# Altium schematic sheet Main.SchDoc
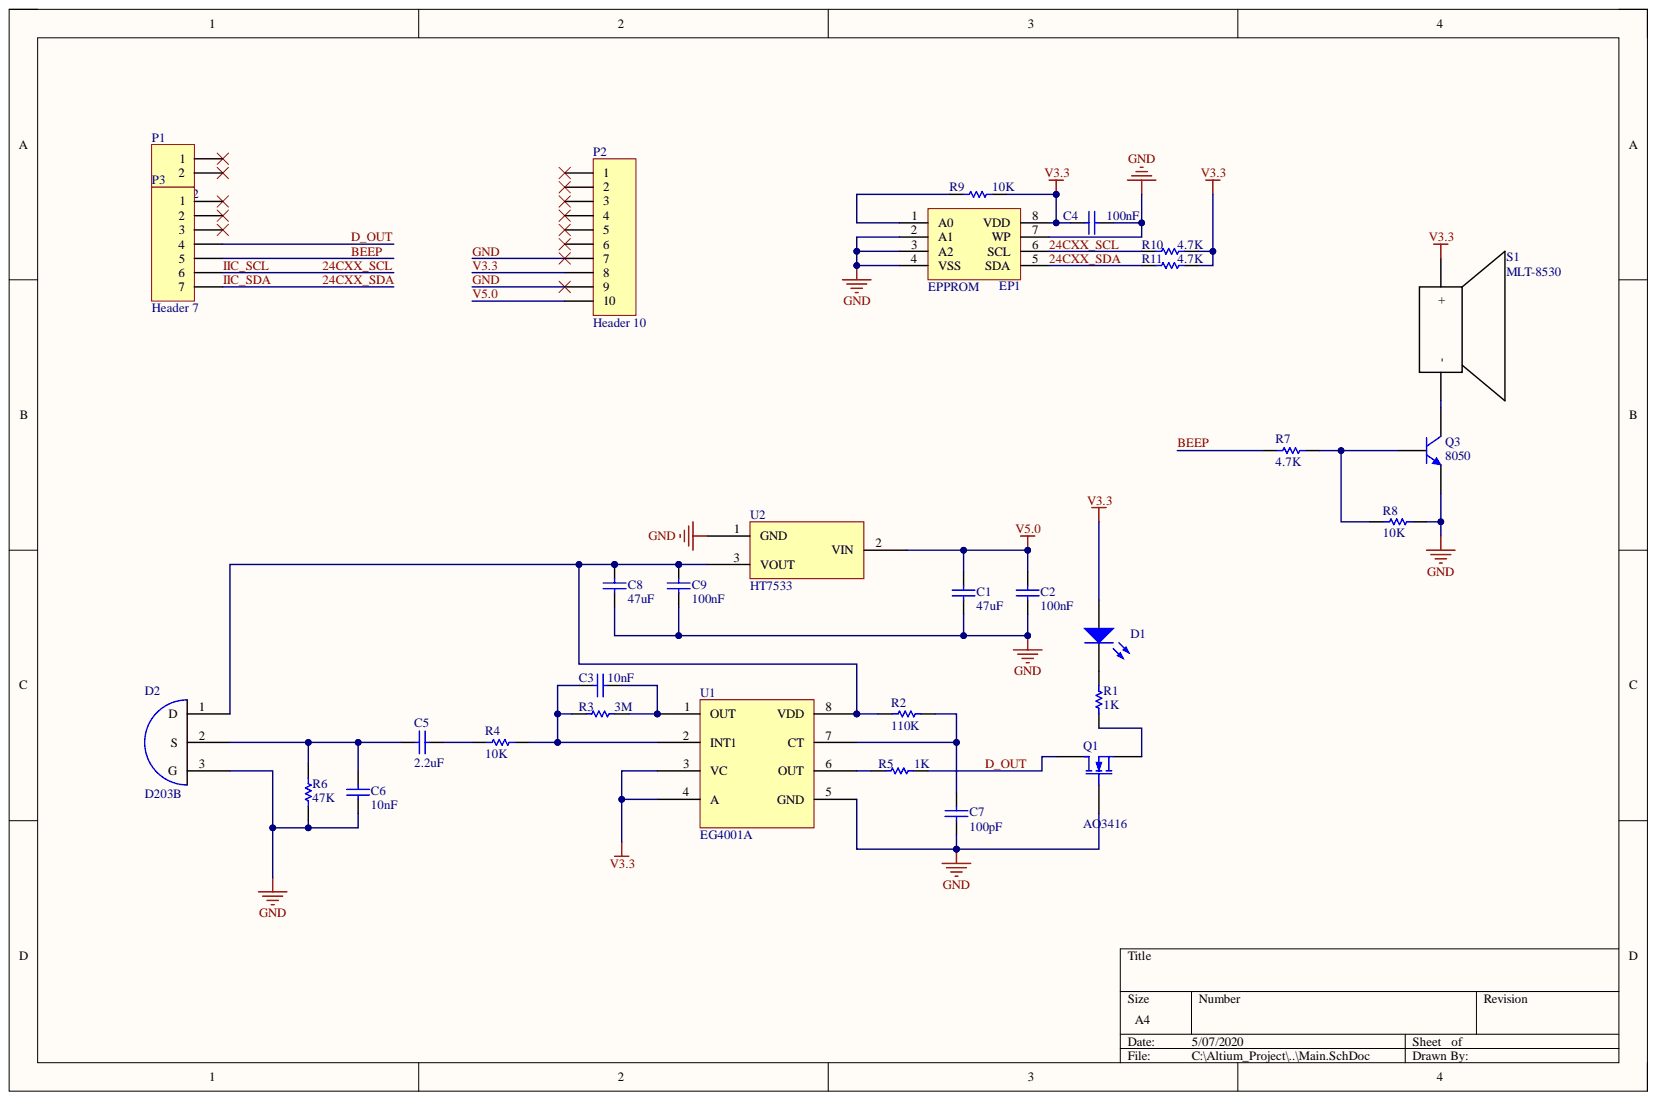
[diagram p0: Main.SchDoc]

## Parts
C1  47uF  [LC-0805_C]
C2  100nF  [C0603]
C3  10nF  [C0603]
C4  100nF  [C0603]
C5  2.2uF  [C0603]
C6  10nF  [C0603]
C7  100pF  [C0603]
C8  47uF  [LC-0805_C]
C9  100nF  [C0603]
D1  LED  [LED_0805]  (value not drawn)
D2  D203B  [D203B]
EP1  EPPROM  [SO-8]
P1  Header 2  [HDR1X2]
P2  Header 10  [HDR1X10]
P3  Header 7  [HDR1X7]
Q1  AO3416  [AO341X]
Q3  8050  [SOT23_N - 123]
R1  1K  [R0603]
R2  110K  [R0603]
R3  3M  [R0603]
R4  10K  [R0603]
R5  1K  [R0603]
R6  47K  [R0603]
R7  4.7K  [R0603]
R8  10K  [R0603]
R9  10K  [R0603]
R10  4.7K  [R0603]
R11  4.7K  [R0603]
S1  MLT-8530  [MLT8530]
U1  EG4001A  [EG4001A]
U2  HT7533  [SOT-89]

## Nets
24CXX_SCL: EP1.6(SCL) P3.6 R10.2
24CXX_SDA: EP1.5(SDA) P3.7 R11.2
BEEP: P3.5 R7.1
D_OUT: P3.4 Q1.1 R5.2
GND: C1.1 C2.1 C4.1 C6.1 C7.1 C8.1 C9.1 D2.3 EP1.2(A1) EP1.3(A2) EP1.4(VSS) EP1.7(WP) P2.7 P2.9 Q1.2 Q3.2 R6.1 R8.2 U1.5(GND) U2.1
V3.3: C4.2 D1.1 EP1.8(VDD) P2.8 R9.2 R10.1 R11.1 S1.1 U1.3(VC) U1.4(A)
V5.0: C1.2 C2.2 P2.10 U2.2
NetC3_1: C3.1 R3.1 R4.2 U1.2(INT1)
NetC3_2: C3.2 R3.2 U1.1(OUT)
NetC5_1: C5.1 C6.2 D2.2 R6.2
NetC5_2: C5.2 R4.1
NetC7_2: C7.2 R2.2 U1.7(CT)
NetC8_2: C8.2 C9.2 D2.1 R2.1 U1.8(VDD) U2.3
NetD1_2: D1.2 R1.2
NetEP1_1: EP1.1(A0) R9.1
NetQ1_3: Q1.3 R1.1
NetQ3_1: Q3.1 R7.2 R8.1
NetQ3_3: Q3.3 S1.2
NetR5_1: R5.1 U1.6(OUT)

Not connected: P1 1 2; P2 1 2 3 4 5 6; P3 1 2 3

## Library data of the parts
C1-C9 = CAP: Capacitor; Component Kind: Standard; Library Name: Devices.IntLib
D1 = LED1: Typical RED GaAs LED; Component Kind: Standard; LatestRevisionDate: 08-Jul-2005; LatestRevisionNote: Mech Layer 1 removed.; Library Name: Devices.IntLib; PackageDescription: LED; 2 Leads; PackageReference: LED-1; Published: 8-Jun-2000; Simulation: LED1; Publisher: Altium Limited
EP1 = EPPROM: ROM: 24C02; Component Kind: Standard; Library Name: IC.IntLib
P1 = Header 2: Header, 2-Pin; Component Kind: Standard; LatestRevisionDate: 17-Jul-2002; LatestRevisionNote: Re-released for DXP Platform.; Library Name: Connectors.IntLib; Signal Integrity: Connector; Publisher: Altium Limited
P2 = Header 10: Header, 10-Pin; Component Kind: Standard; LatestRevisionDate: 17-Jul-2002; LatestRevisionNote: Re-released for DXP Platform.; Library Name: Connectors.IntLib; Signal Integrity: Connector; Publisher: Altium Limited
P3 = Header 7: Header, 7-Pin; Component Kind: Standard; LatestRevisionDate: 17-Jul-2002; LatestRevisionNote: Re-released for DXP Platform.; Library Name: Connectors.IntLib; Signal Integrity: Connector; Publisher: Altium Limited
Q1 = MOSFET-N: N-Channel MOSFET; Component Kind: Standard; Library Name: Devices.IntLib; Simulation: NMOS
Q3 = NPN: NPN Bipolar Transistor; Code_JEDEC: TO-226-AA; Component Kind: Standard; LatestRevisionDate: 17-Jul-2002; LatestRevisionNote: Re-released for DXP Platform.; Library Name: Devices.IntLib; PackageDescription: TO, Flat Index; 3 In-Line, Axial Leads; Body Dia. 4.8mm; Leads 0.55 x 0.51 mm (max); PackageReference: TO-226-AA; Published: 8-Jun-2000; Signal Integrity: NPN; Simulation: NPN; Publisher: Altium Limited
R1 R5 = Res1: Resistor; Component Kind: Standard; LatestRevisionDate: 17-Jul-2002; LatestRevisionNote: Re-released for DXP Platform.; Library Name: Devices.IntLib; PackageDescription: Axial Device, Thru-Hole; 2 Leads; 0.3 in Pin Spacing; PackageReference: AXIAL-0.3; Published: 8-Jun-2000; Signal Integrity: Res; Simulation: RESISTOR; Value: 1K; Publisher: Altium Limited
R2-R4 R6-R11 = Res1: Resistor; Value: 1K; Component Kind: Standard; LatestRevisionDate: 17-Jul-2002; LatestRevisionNote: Re-released for DXP Platform.; Library Name: Devices.IntLib; PackageDescription: Axial Device, Thru-Hole; 2 Leads; 0.3 in Pin Spacing; PackageReference: AXIAL-0.3; Published: 8-Jun-2000; Signal Integrity: Res; Simulation: RESISTOR; Publisher: Altium Limited
D2 = D203B: Component Kind: Standard; Library Name: Sensor.IntLib
S1 = MLT-8530: Component Kind: Standard; Library Name: Custom.IntLib
U1 = EG4001A: Component Kind: Standard; Library Name: Sensor.IntLib
U2 = HT7533: Component Kind: Standard; Library Name: Sensor.IntLib
